# Altium schematic sheet Sheet1.SchDoc
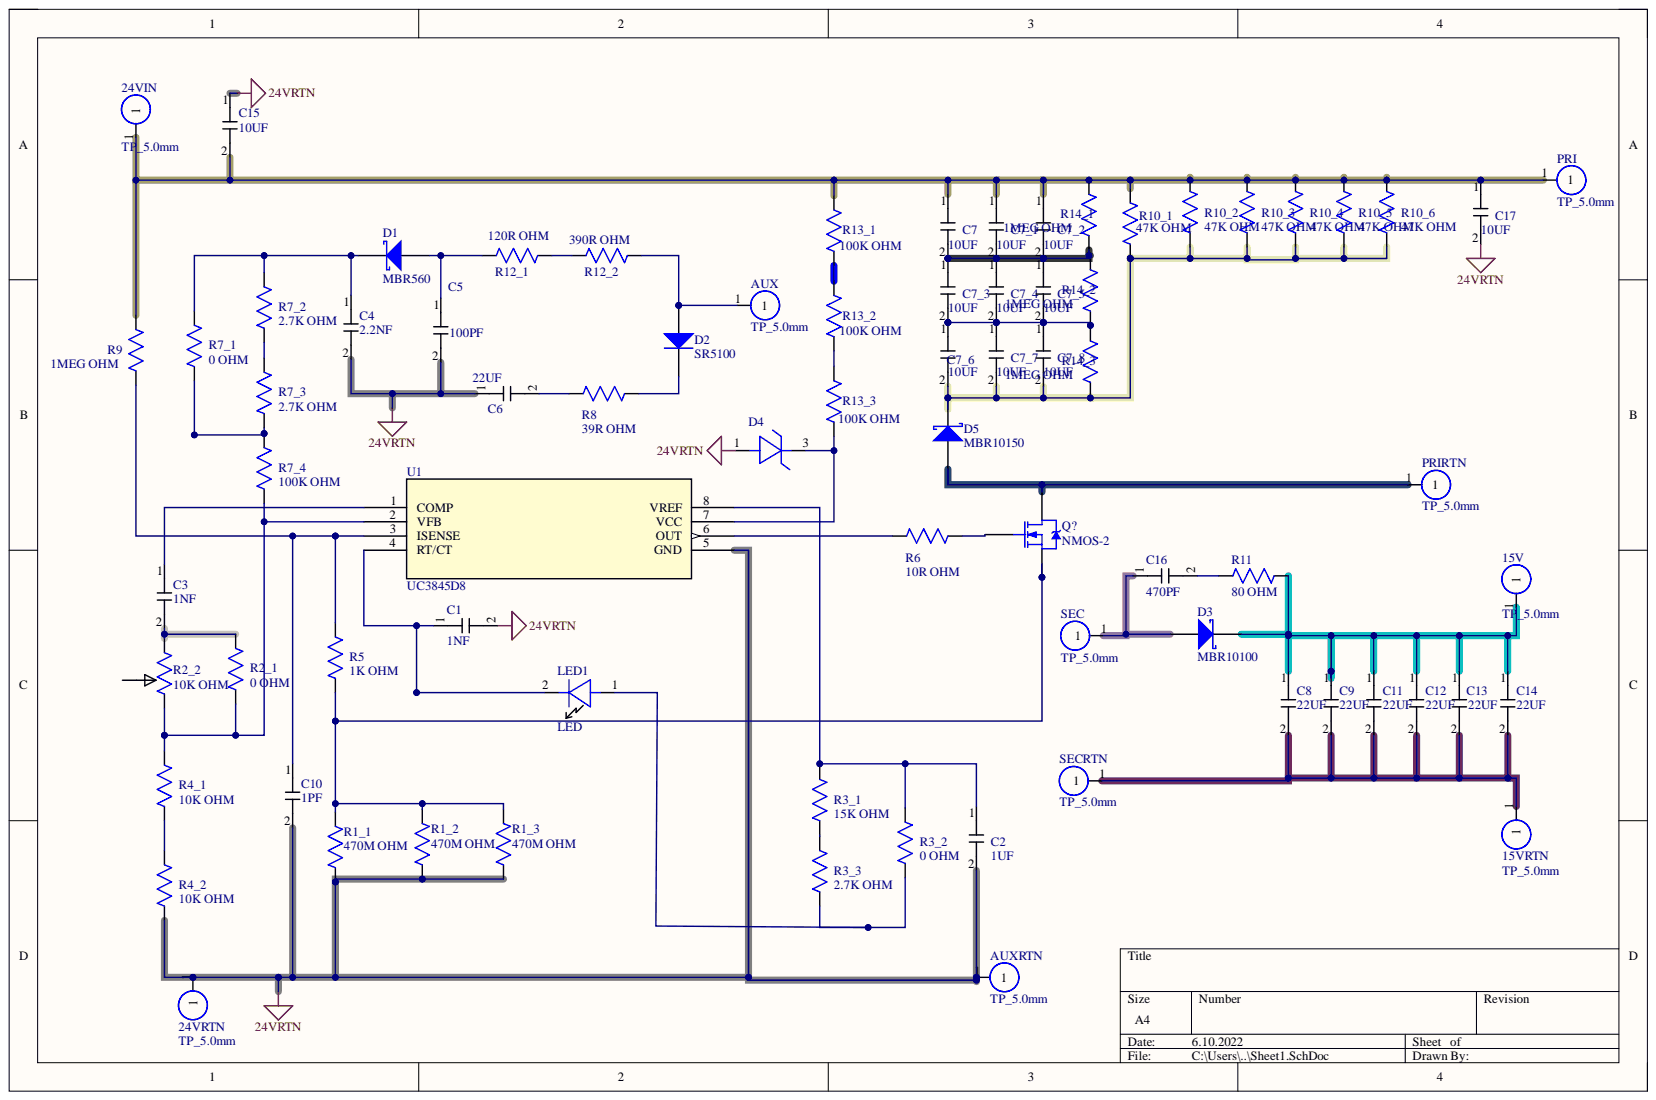
[diagram p0: Sheet1.SchDoc]

## Parts
15V  TP_5.0mm  [tp_5.0]
15VRTN  TP_5.0mm  [tp_5.0]
24VIN  TP_5.0mm  [tp_5.0]
24VRTN  TP_5.0mm  [tp_5.0]
AUX  TP_5.0mm  [tp_5.0]
AUXRTN  TP_5.0mm  [tp_5.0]
C1  1NF  [1206N]
C2  1UF  [1206N]
C3  1NF  [1206N]
C4  2.2NF  [1206N]
C5  100PF  [1206N]
C6  22UF  [1206N]
C7  10UF  [1206N]
C7_1  10UF  [1206N]
C7_2  10UF  [1206N]
C7_3  10UF  [1206N]
C7_4  10UF  [1206N]
C7_5  10UF  [1206N]
C7_6  10UF  [1206N]
C7_7  10UF  [1206N]
C7_8  10UF  [1206N]
C8  22UF  [0805L]
C9  22UF  [0805L]
C10  1PF  [1206N]
C11  22UF  [0805L]
C12  22UF  [0805L]
C13  22UF  [0805L]
C14  22UF  [0805L]
C15  10UF  [1206N]
C16  470PF  [1206N]
C17  10UF  [1206N]
D1  MBR560  [TO-220AC]
D2  SR5100  [DO-201AD]
D3  MBR10100  [TO-220AC]
D4  BZX84C15LT1  [SOT23_190P290X240X111_3]  (value not drawn)
D5  MBR10150  [TO-220AC]
LED1  LED  [LEDC_100X60X20_050X060M]
PRI  TP_5.0mm  [tp_5.0]
PRIRTN  TP_5.0mm  [tp_5.0]
Q?  NMOS-2  [TO-220AB]
R1_1  470M OHM  [2512N]
R1_2  470M OHM  [2512N]
R1_3  470M OHM  [2512N]
R2_1  0 OHM  [1206L]
R2_2  10K OHM  [pot_3224j]
R3_1  15K OHM  [1206L]
R3_2  0 OHM  [1206L]
R3_3  2.7K OHM  [1206L]
R4_1  10K OHM  [1206L]
R4_2  10K OHM  [1206L]
R5  1K OHM  [1206L]
R6  10R OHM  [1206L]
R7_1  0 OHM  [1206L]
R7_2  2.7K OHM  [1206L]
R7_3  2.7K OHM  [1206L]
R7_4  100K OHM  [1206L]
R8  39R OHM  [1206L]
R9  1MEG OHM  [1206L]
R10_1  47K OHM  [1206L]
R10_2  47K OHM  [1206L]
R10_3  47K OHM  [1206L]
R10_4  47K OHM  [1206L]
R10_5  47K OHM  [1206L]
R10_6  47K OHM  [1206L]
R11  80 OHM  [1206L]
R12_1  120R OHM  [1206L]
R12_2  390R OHM  [1206L]
R13_1  100K OHM  [1206L]
R13_2  100K OHM  [1206L]
R13_3  100K OHM  [1206L]
R14_1  1MEG OHM  [1206L]
R14_2  1MEG OHM  [1206L]
R14_3  1MEG OHM  [1206L]
SEC  TP_5.0mm  [tp_5.0]
SECRTN  TP_5.0mm  [tp_5.0]
U1  UC3845D8  [D8]

## Nets
24VRTN: 24VRTN.1 AUXRTN.1 C1.2 C2.2 C4.2 C5.2 C6.1 C10.2 C15.1 C17.2 D4.1 R1_1.1 R1_2.1 R1_3.1 R4_2.1 U1.5(GND)
Net15V_1: 15V.1 C8.1 C9.1 C11.1 C12.1 C13.1 C14.1 D3.1 R11.1
Net15VRTN_1: 15VRTN.1 C8.2 C9.2 C11.2 C12.2 C13.2 C14.2 SECRTN.1
Net24VIN_1: 24VIN.1 C7.1 C7_1.1 C7_2.1 C15.2 C17.1 PRI.1 R9.2 R10_1.1 R10_2.1 R10_3.1 R10_4.1 R10_5.1 R10_6.1 R13_1.2 R14_1.2
NetAUX_1: AUX.1 D2.1 R12_2.1
NetC1_1: C1.1 LED1.2 U1.4(RT/CT)
NetC2_1: C2.1 R3_1.2 R3_2.2 U1.8(VREF)
NetC3_1: C3.1 U1.1(COMP)
NetC3_2: C3.2 R2_1.2 R2_2.1
NetC4_1: C4.1 D1.1 R7_1.2 R7_2.2
NetC5_1: C5.1 D1.3 R12_1.2
NetC6_2: C6.2 R8.2
NetC7_1_2: C7.2 C7_1.2 C7_2.2 C7_3.1 C7_4.1 C7_5.1 R14_1.1 R14_2.2
NetC7_3_2: C7_3.2 C7_4.2 C7_5.2 C7_6.1 C7_7.1 C7_8.1 R14_2.1 R14_3.2
NetC7_6_2: C7_6.2 C7_7.2 C7_8.2 D5.1 R10_1.2 R10_2.2 R10_3.2 R10_4.2 R10_5.2 R10_6.2 R14_3.1
NetC10_1: C10.1 R5.2 R9.1 U1.3(ISENSE)
NetC16_1: C16.1 D3.3 SEC.1
NetC16_2: C16.2 R11.2
NetD2_2: D2.2 R8.1
NetD4_3: D4.3 R13_3.1 U1.7(VCC)
NetD5_3: D5.3 PRIRTN.1 Q?.2
NetLED1_1: LED1.1 R3_2.1 R3_3.1
NetQ?_1: Q?.1 R6.1
NetQ?_3: Q?.3 R1_1.2 R1_2.2 R1_3.2 R5.1
NetR2_1_1: R2_1.1 R2_2.3 R4_1.2 R7_4.1 U1.2(VFB)
NetR3_1_1: R3_1.1 R3_3.2
NetR4_1_1: R4_1.1 R4_2.2
NetR6_2: R6.2 U1.6(OUT)
NetR7_1_1: R7_1.1 R7_3.1 R7_4.2
NetR7_2_1: R7_2.1 R7_3.2
NetR12_1_1: R12_1.1 R12_2.2
NetR13_1_1: R13_1.1 R13_2.2
NetR13_2_1: R13_2.1 R13_3.2

Not connected: R2_2 2

## Library data of the parts
15V 15VRTN 24VIN 24VRTN AUX AUXRTN PRI PRIRTN SEC SECRTN = TP_5.0mm: 5mm; Component Kind: Standard; Library Name: Integrated_Library.IntLib
C1-C7 C7_1-C7_8 C10 C15-C17 = 10023689-135: CAP,1UF(16V,10%,CER,X7R,SMD-1206); MFG_PART_NO: C1206C105K4RAC; DESIGNER: M.B.HAVUR/ T.H.KARAKAYA; GEOMETRY_TYPE: SMD; LEAD_FREE_TYPE: KURSUNSUZ; MFG: KEMET; OPERATING_TEMPERATURE: Military (-55/+125 C); PREPARED_BY: BIROL GURSOY; APPROVE_DATE: 13.10.2005 / 19.09.2014; CATALOG_NO: --; Component Kind: Standard; MGC_COMP: 1UF; Library Name: MGEO_ALT_LIB.IntLib
C8 C9 C11-C14 = 30000061: CAP,22UF(25VDC,20%,CER,X5R,SMD-0805); MFG_PART_NO: GRM21BR61E226ME44; CATALOG_NO: 2008/20; DESIGNER: T OĞUZ / HALIL IBRAHIM YILDIRIM; GEOMETRY_TYPE: SMD; LEAD_FREE_TYPE: KURSUNSUZ; MFG: MURATA; OPERATING_TEMPERATURE: (-55/+85 C); PREPARED_BY: RUSTU OKTEN; APPROVE_DATE: 29.11.2011 / 03.01.2018; Component Kind: Standard; MGC_COMP: 22UF; Library Name: MGEO_ALT_LIB.IntLib
D1 D3 D5 = Diode 10TQ035: Schottky Rectifier; Note: Terminal 2 is the TAB and is electrically connected to the cathode; Component Kind: Standard; ComponentLink1Description: Manufacturer Link; ComponentLink1URL: http://www.irf.com/; ComponentLink2Description: Datasheet; ComponentLink2URL: http://www.irf.com/product-info/datasheets/data/10tq.pdf; DatasheetVersion: PD-2.057B; LatestRevisionDate: 17-Jul-2002; LatestRevisionNote: Re-released for DXP Platform.; Library Name: Miscellaneous Devices.IntLib; PackageDescription: TO, Thru-Hole, Vertical, Heatsink Mounted; 3 In-Line Leads (1 Absent); Pitch 2.54 mm; PackageReference: TO-220AC; PackageVersion: Feb-1998; Published: 8-Jun-2000; Simulation: 10TQ035; Publisher: Altium Limited
D2 = Diode 1N5401: 3 Amp General Purpose Rectifier; Component Kind: Standard; ComponentLink1Description: Manufacturer Link; ComponentLink1URL: http://www.fairchildsemi.com/; ComponentLink2Description: Datasheet; ComponentLink2URL: http://www.fairchildsemi.com/ds/1N%2F1N5400.pdf; DatasheetVersion: 1998; LatestRevisionDate: 17-Jul-2002; LatestRevisionNote: Re-released for DXP Platform.; Library Name: Miscellaneous Devices.IntLib; PackageDescription: Diode, Axial; Body 9.5 x 5.6 mm (LxDia. max), Lead Dia. 1.32 mm (max); PackageReference: DO-201AD; PackageVersion: Aug-1999; Published: 8-Jun-2000; Signal Integrity: 1N5401; Simulation: 1N5401; Publisher: Altium Limited
D4 = 5961-1315-0002: DIODE(ZNR,15V,SOT-23); CATALOG_NO: 2010/54; DESIGNER: A.COBAN / T.H.KARAKAYA; GEOMETRY_TYPE: SMD; LEAD_FREE_TYPE: KURSUNLU; MFG: ON SEMICONDUCTOR; OPERATING_TEMPERATURE: (-65/+150 C); PREPARED_BY: BIROL GURSOY; APPROVE_DATE: 15.07.2010 / 19.09.2014; Component Kind: Standard; MFG_PART_NO: BZX84C15LT1; MGC_COMP: BZX84C15LT1; Library Name: MGEO_ALT_LIB.IntLib
LED1 = 10063431: LED (GRN, SMD, 20MA); MFG_PART_NO: SML-P11MTT86; CATALOG_NO: 2183; DESIGNER: B.CAGLAR / R.S.SUNGU; LEAD_FREE_TYPE: KURSUNLU; MFG: Rohm; OPERATING_TEMPERATURE: Industrial (-40C/+85 C); PREPARED_BY: BIROL GURSOY; APPROVE_DATE: 09.04.2010 / 09.01.2015; Component Kind: Standard; GEOMETRY_TYPE: SMD; MGC_COMP: LED; Library Name: MGEO_ALT_LIB.IntLib
Q? = NMOS-2: N-Channel Power MOSFET; Note: Pin 2 is electrically connected to pin 4.; Component Kind: Standard; ComponentLink1Description: Manufacturer Link; ComponentLink1URL: http://www.irf.com/; DatasheetVersion: PD-9.814; LatestRevisionDate: 17-Jul-2002; LatestRevisionNote: Re-released for DXP Platform.; Library Name: Miscellaneous Devices.IntLib; PackageDescription: TO, Thru-Hole, Vertical, Heatsink Mounted; 3 In-Line Leads; Pitch 2.54 mm; PackageReference: TO-220AB; PackageVersion: Feb-1997; Published: 8-Jun-2000; Simulation: IRF1010; Publisher: Altium Limited
R1_1-R1_3 = 30007383: RES,3M(THFL,2W,1%,SMD-2512); MFG_PART_NO: PMR100HZPFV3L00; CATALOG_NO: 6088; DESIGNER: HALIL ERTUGRUL; GEOMETRY_TYPE: SMD; LEAD_FREE_TYPE: KURSUNSUZ; MFG: ROHM; OPERATING_TEMPERATURE: (-55/+155 C); PREPARED_BY: RUSTU OKTEN; APPROVE_DATE: 10.06.2017; Component Kind: Standard; MGC_COMP: 470M OHM; Library Name: MGEO_ALT_LIB.IntLib
R2_1 R3_1-R3_3 R4_1 R4_2 R5 R6 R7_1-R7_4 R8 R9 R10_1-R10_6 R11 R12_1 R12_2 R13_1-R13_3 R14_1-R14_3 = 10023672-5: RES,0R(THFL,0.250W,0%,SMD-1206); MFG_PART_NO: CRCW12060000Z0EA; CATALOG_NO: ---; DESIGNER: M.B.HAVUR; GEOMETRY_TYPE: SMD; LEAD_FREE_TYPE: KURŞUNSUZ; MFG: VISHAY; OPERATING_TEMPERATURE: Military (-55/+125 C); PREPARED_BY: BIROL GURSOY; APPROVE_DATE: 29.09.2005; Component Kind: Standard; R13_1: 0R; Library Name: MGEO_ALT_LIB.IntLib
R2_2 = 5905-9993-1034: POT,10KR(CRMT_LIN,0.25W,10%,MULT,SMD); MFG_PART_NO: 3224J-1-103M; CATALOG_NO: 2007/10; DESIGNER: EMRE KILICKAYA / SELCUK BILIR; LEAD_FREE_TYPE: KURSUNSUZ; MFG: BOURNS; OPERATING_TEMPERATURE: (-65/+150 C); PREPARED_BY: RUSTU OKTEN; APPROVE_DATE: 02.07.2008 / 05.12.2017; Component Kind: Standard; GEOMETRY_TYPE: SMD; MGC_COMP: 10K OHM; Library Name: MGEO_ALT_LIB.IntLib
U1 = UC3845D8: No Description Available; Mfr_Name: Texas Instruments; Component Kind: Standard; Library Name: UC3845D8.IntLib; Manufacturer_Part_Number: UC3845D8; RefDes: RefDes; Type: DEV; Copyright: Copyright (C) 2022 Ultra Librarian. All rights reserved.
